# Altium schematic sheet OpenHEG.SchDoc(OpenHEG)
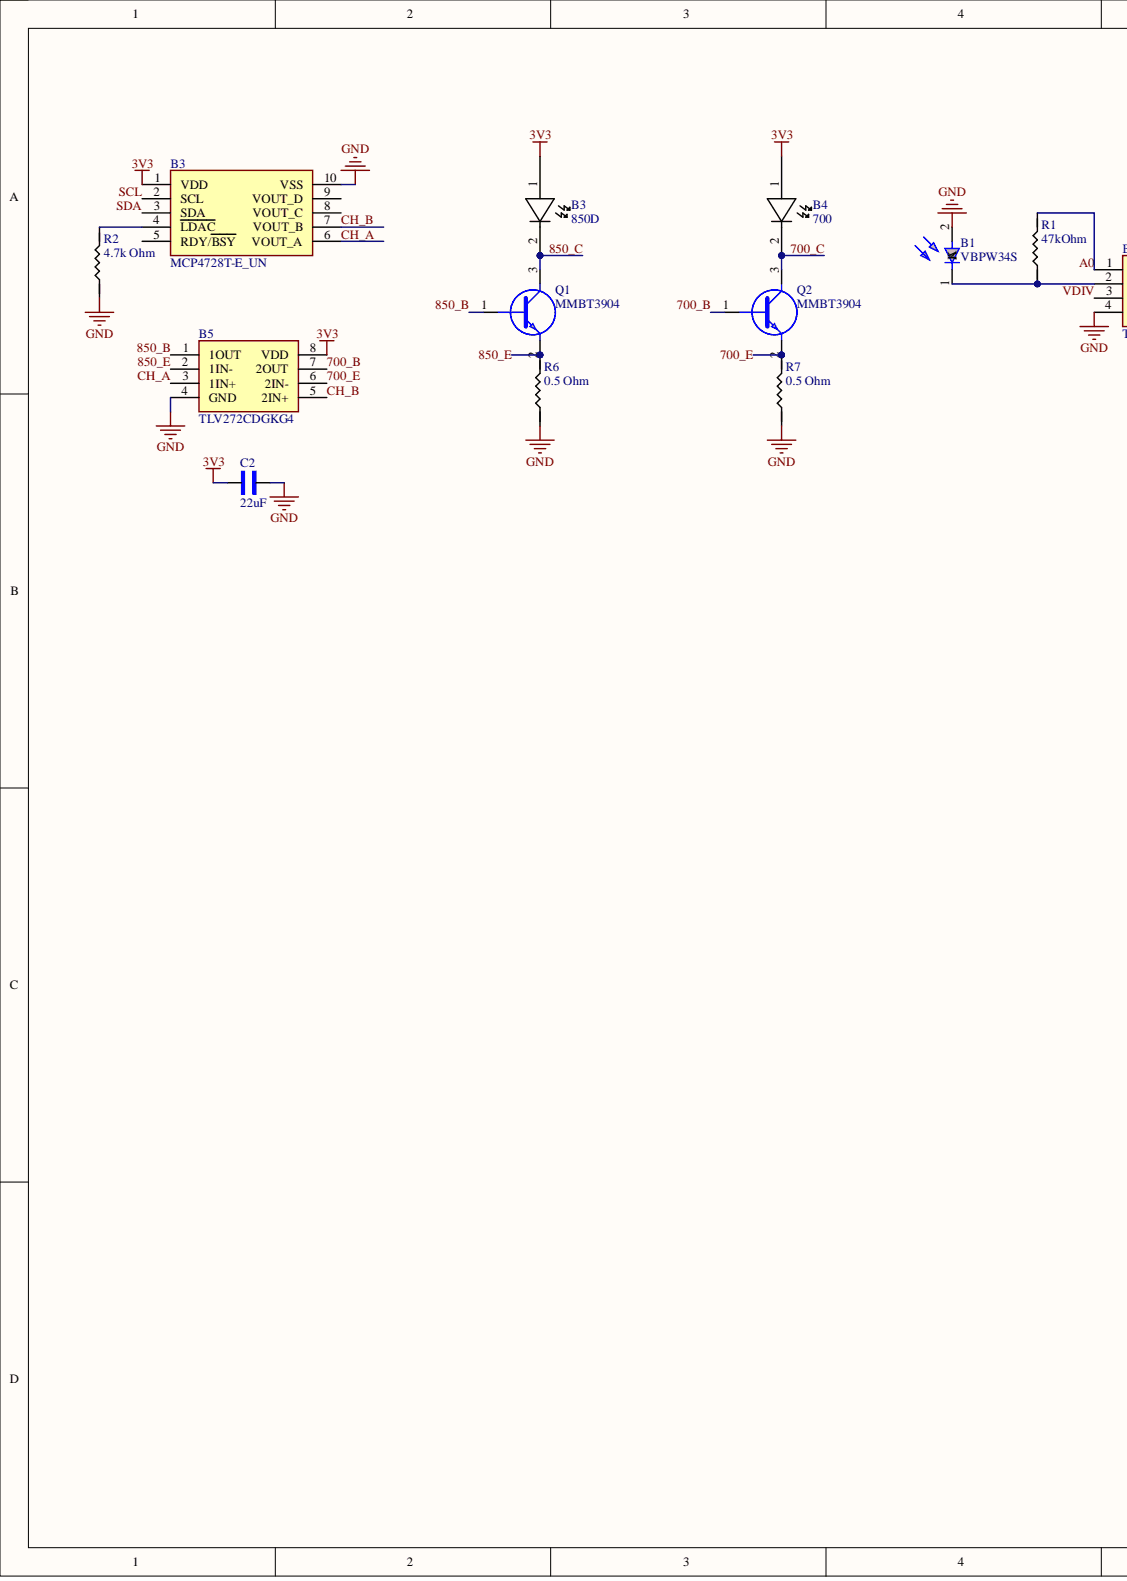
[diagram p0: OpenHEG.SchDoc, left]
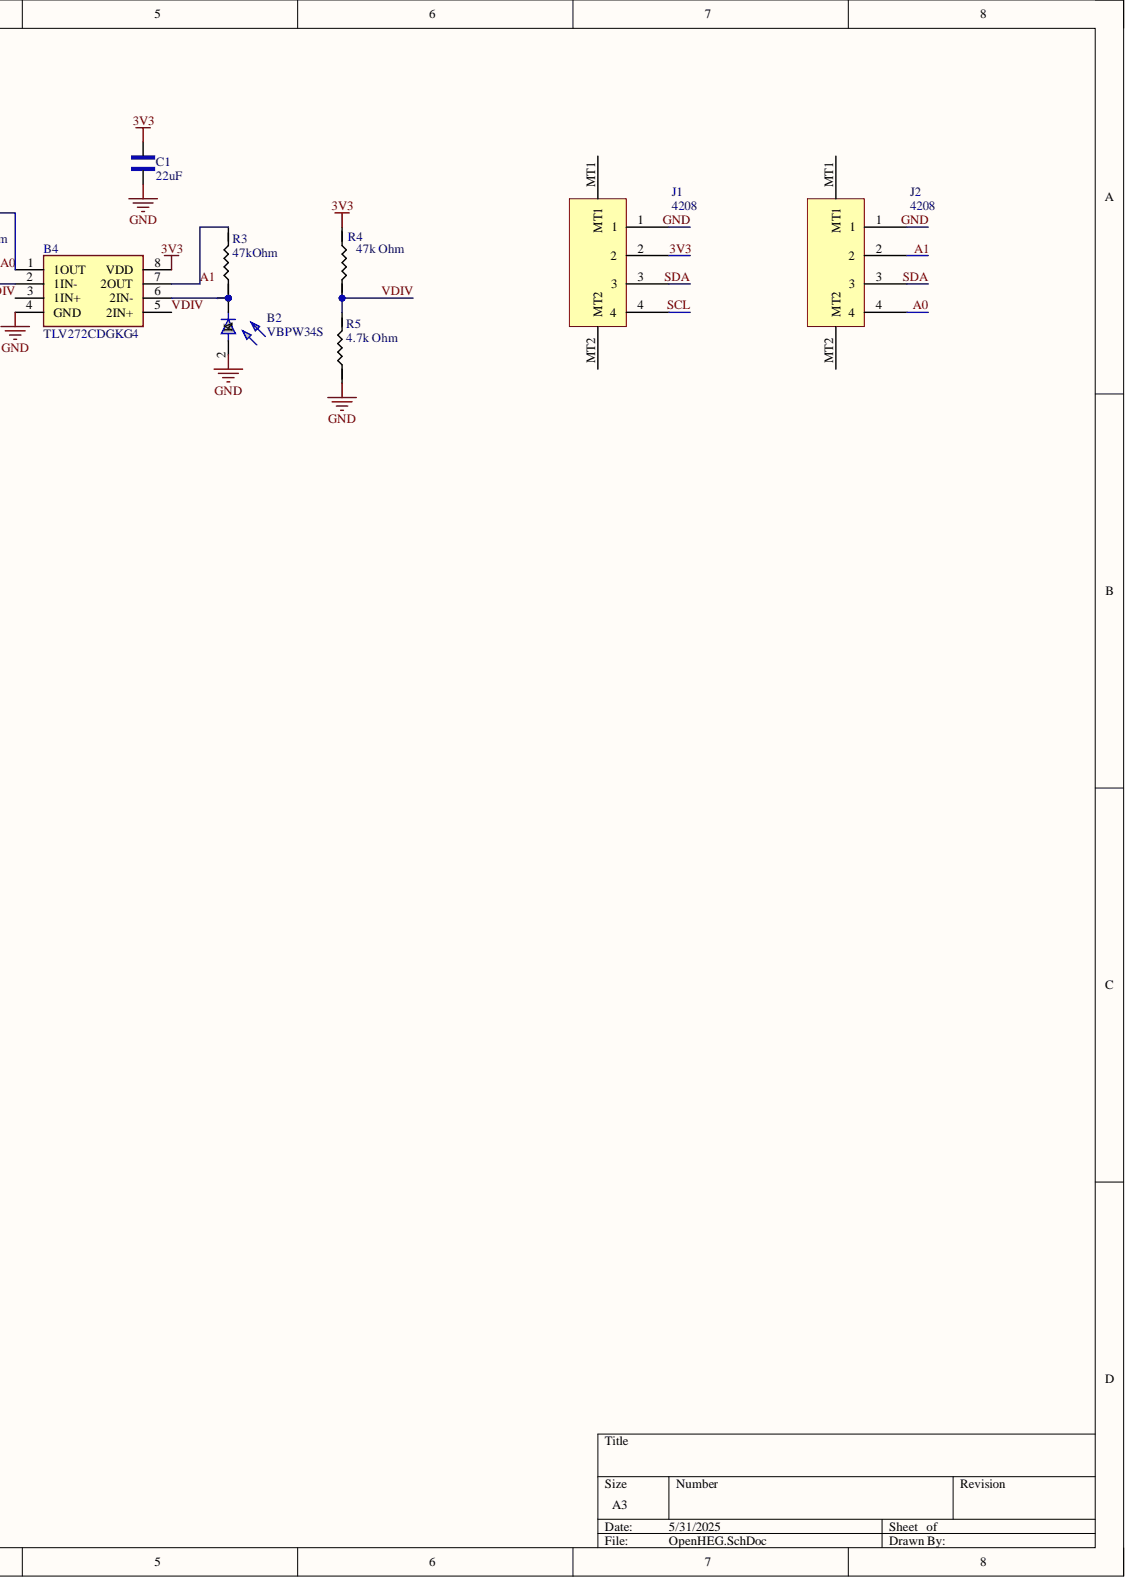
[diagram p1: OpenHEG.SchDoc, right]

## Parts
B1  VBPW34S
B2  VBPW34S
B3  850D
B3  MCP4728T-E_UN
B4  TLV272CDGKG4
B4  700
B5  TLV272CDGKG4
C1  22uF
C2  22uF
J1  4208
J2  4208
Q1  MMBT3904
Q2  MMBT3904
R1  47k Ohm  value 47kOhm
R2  4.7k Ohm
R3  47k Ohm  value 47kOhm
R4  47kOhm  value 47k Ohm
R5  4.7k Ohm
R6  0.5 Ohm
R7  0.5 Ohm

## Nets
3V3: B3.1 B4.1 B4.8(VDD) B5.8(VDD) C1.1 C2.2 J1.2 R4.1
700_B: B5.7(2OUT) Q2.1
700_C: B4.2 Q2.3
700_E: B5.6(2IN-) Q2.2 R7.2
850_B: B5.1(1OUT) Q1.1
850_C: B3.2 Q1.3
850_E: B5.2(1IN-) Q1.2 R6.2
A0: B4.1 J2.4 R1.2
A1: B4.7(2OUT) J2.2 R3.2
CH_A: B3.6(VOUT_A) B5.3(1IN+)
CH_B: B3.7(VOUT_B) B5.5(2IN+)
GND: B1.2 B2.2 B3.10(VSS) B4.4(GND) B5.4(GND) C1.2 C2.1 J1.1 J2.1 R2.1 R5.1 R6.1 R7.1
SCL: B3.2 J1.4
SDA: B3.3(SDA) J1.3 J2.3
VDIV: B4.3(1IN+) B4.5(2IN+) R4.2 R5.2
NetB1_1: B1.1 B4.2 R1.1
NetB2_1: B2.1 B4.6(2IN-) R3.1
NetB3_4: B3.4(LDAC) R2.2

Not connected: B3 5(RDY/BSY) 8(VOUT_C) 9(VOUT_D); J1 MT1 MT2; J2 MT1 MT2

## Library data of the parts
B1 B2 = CMP-006-000002-2: Photodiode PIN Chip 940nm 2-Pin SMD T/R; Manufacturer_Name: Vishay; Geometry.Height: 1.4mm; Arrow Part Number: VBPW34S; Arrow Price/Stock: https://www.arrow.com/en/products/vbpw34s/vishay?utm_currency=USD&region=nac; Component Kind: Standard; Datasheet Link: https://componentsearchengine.com/Datasheets/2/VBPW34S.pdf; Design Item ID: VBPW34S; Manufacturer_Part_Number: VBPW34S; Mouser Part Number: 782-VBPW34S; Mouser Price/Stock: https://www.mouser.co.uk/ProductDetail/Vishay-Semiconductors/VBPW34S?qs=Uj7aA%252BCqp8ZDwjFKEZ8K8A%3D%3D; Source: VBPW34S.SchLib; Library Name: Mister Industries
B3 B4 = CMP-022-000000-1: Component Kind: Standard; Design Item ID: 805D; Source: SMT850.SchLib; Library Name: Mister Industries
B3 = CMP-009-000002-1: Digital to Analog Converters - DAC Quad 12-bit NV DAC with I2C interface; Manufacturer_Name: Microchip; Manufacturer_Part_Number: MCP4728T-E/UN; Geometry.Height: 1.1mm; Arrow Part Number: MCP4728T-E/UN; Arrow Price/Stock: https://www.arrow.com/en/products/mcp4728t-eun/microchip-technology?utm_currency=USD&region=nac; Component Kind: Standard; Datasheet Link: https://componentsearchengine.com/Datasheets/2/MCP4728T-E_UN.pdf; Design Item ID: MCP4728T-E_UN; Mouser Part Number: 579-MCP4728T-E/UN; Mouser Price/Stock: https://www.mouser.co.uk/ProductDetail/Microchip-Technology/MCP4728T-E-UN?qs=E6wAi2XWqcPJxULNRq0fKQ%3D%3D; Source: MCP4728T-E_UN.SchLib; Library Name: Mister Industries
B4 B5 = CMP-003-000001-2: Operational Amplifiers - Op Amps 550-uA/Ch 3-MHz Rail-to-Rail Out; Manufacturer_Name: Texas Instruments; Geometry.Height: 1.1mm; Component Kind: Standard; Datasheet Link: https://www.ti.com/lit/ds/symlink/tlv272.pdf?ts=1621864530946&ref_url=https%253A%252F%252Fwww.ti.com%252Fproduct%252FTLV272; Design Item ID: TLV272CDGKG4; Manufacturer_Part_Number: TLV272CDGKG4; Mouser Part Number: 595-TLV272CDGKG4; Mouser Price/Stock: https://www.mouser.com/Search/Refine.aspx?Keyword=595-TLV272CDGKG4; Source: TLV272CDGKG4.SchLib; Library Name: Mister Industries
C1 C2 = C_22uF_1206: 22uF ±10% 25V X5R 1206 Multilayer Ceramic Capacitors MLCC - SMD/SMT RoHS; MFR Part #: CL31A226KAHNNNE; Type: basic; Component Kind: Standard; Datasheet: https://datasheet.lcsc.com/lcsc/1811031514_Samsung-Electro-Mechanics-CL31A226KAHNNNE_C12891.pdf; LCSC Part#: C12891; Library Name: ECEN5730Lib_2023_05_DS (2).IntLib; Value: 22uF
J1 J2 = CMP-005-000000-1: Adafruit Accessories JST SH 4-pin Right Angle Connector (10-pack) - Qwiic Compatible; Component Kind: Standard; Component Type: Connectors; Datasheet Link: https://cdn-shop.adafruit.com/product-files/4390/4390_C13925-001_CCP-W20-04-BK-SMT-R-A.PDF; Design Item ID: 4208; Height: 7.05mm; Manufacturer_Name: Adafruit; Manufacturer_Part_Number: 4208; Pin Count: 6; Source: SamacSys.SchLib; Library Name: Mister Industries
Q1 Q2 = CMP-017-000000-1: SOT-23-3; Manufacturer_Name: onsemi; Geometry.Height: 1.2mm; Arrow Part Number: MMBT3904; Arrow Price/Stock: https://www.arrow.com/en/products/mmbt3904/on-semiconductor; Component Kind: Standard; Datasheet Link: https://www.onsemi.com/download/data-sheet/pdf/PZT3904-D.pdf; Design Item ID: MMBT3904; Manufacturer_Part_Number: MMBT3904; Mouser Part Number: 512-MMBT3904; Mouser Price/Stock: https://www.mouser.co.uk/ProductDetail/onsemi-Fairchild/MMBT3904?qs=UMEuL5FsraCg3xKjS4uBJQ%3D%3D; Source: MMBT3904.SchLib; Library Name: Mister Industries
R1 R3 R4 = R_2Ohm_0603: CHIP RESISTOR - SURFACE MOUNT 47kOHMS ±1% 1/10W 0603 ROHS; MFR Part #: 0603WAF200KT5E; Component Kind: Standard; Datasheet: https://datasheet.lcsc.com/szlcsc/Uniroyal-Elec-0603WAF200KT5E_C22977.pdf; LCSC Part#: C22977; Library Name: ECEN5730Lib_2023_05_DS (2).IntLib; Type: Basic
R2 R5 = R_2Ohm_0603: CHIP RESISTOR - SURFACE MOUNT 4.7kOHMS ±1% 1/10W 0603 ROHS; MFR Part #: 0603WAF200KT5E; Component Kind: Standard; Datasheet: https://datasheet.lcsc.com/szlcsc/Uniroyal-Elec-0603WAF200KT5E_C22977.pdf; LCSC Part#: C22977; Library Name: ECEN5730Lib_2023_05_DS (2).IntLib; Type: Basic; Value: 4.7k Ohm
R6 R7 = R_2Ohm_0603: CHIP RESISTOR - SURFACE MOUNT 0.5OHMS ±1% 1/10W 0603 ROHS; MFR Part #: 0603WAF200KT5E; Component Kind: Standard; Datasheet: https://datasheet.lcsc.com/szlcsc/Uniroyal-Elec-0603WAF200KT5E_C22977.pdf; LCSC Part#: C22977; Library Name: ECEN5730Lib_2023_05_DS (2).IntLib; Type: Basic; Value: 0.5 Ohm
